# Altium schematic sheet EIT_CURRENTSTAGE.SchDoc
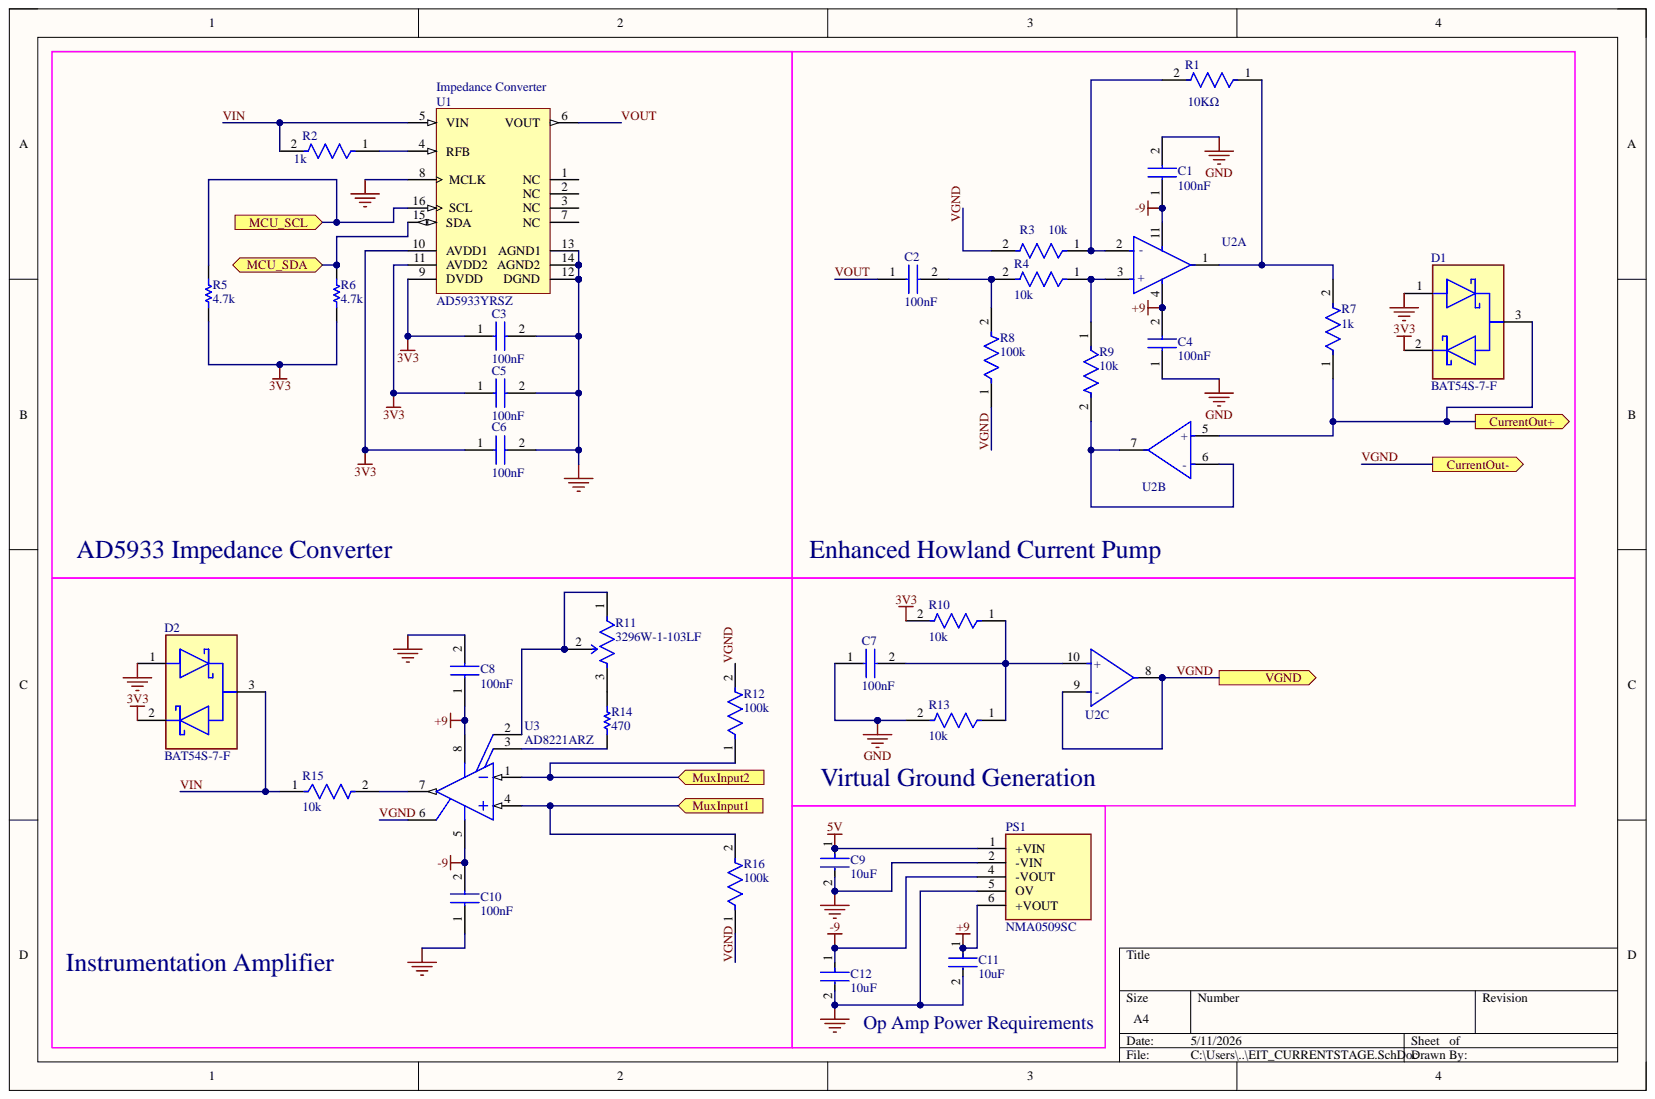
[diagram p0: EIT_CURRENTSTAGE.SchDoc]

## Parts
C1  100nF  [CAPC1608X90N]
C2  100nF  [CAPC1608X90N]
C3  100nF  [CAPC1608X90N]
C4  100nF  [CAPC1608X90N]
C5  100nF  [CAPC1608X90N]
C6  100nF  [CAPC1608X90N]
C7  100nF  [CAPC1608X90N]
C8  100nF  [CAPC1608X90N]
C9  10uF  [FP-CL21-IPC_B]
C10  100nF  [CAPC1608X90N]
C11  10uF  [FP-CL21-IPC_B]
C12  10uF  [FP-CL21-IPC_B]
D1  BAT54S-7-F  [FP-SOT23-MFG]
D2  BAT54S-7-F  [FP-SOT23-MFG]
PS1  NMA0509SC  [NMA0512SC]
R1  value 10KΩ  Resistance: 10KΩ  [FP-RT0603-MFG]  (hidden comment: RT0603BRD0710KL)
R2  1k  [FP-RT0603-MFG]
R3  10k  [FP-RT0603-MFG]
R4  10k  [FP-RT0603-MFG]
R5  4.7k  [RESC1608X55X25LL10T15]
R6  4.7k  [RESC1608X55X25LL10T15]
R7  1k  [FP-RT0603-MFG]
R8  100k  [FP-RQ731J-IPC_C]
R9  10k  [FP-RT0603-MFG]
R10  10k  [FP-RT0603-MFG]
R11  3296W-1-103LF  [FP-3296W-MFG]
R12  100k  [FP-RQ731J-IPC_C]
R13  10k  [FP-RT0603-MFG]
R14  470  [RESC1608X55X25LL10T15]
R15  10k  [FP-RT0603-MFG]
R16  100k  [FP-RQ731J-IPC_C]
U1  AD5933YRSZ  [ADI-RS-16_L]
U2  OPA4192IPW  [FP-PW0014A-IPC_C]  (value not drawn)  (parts A,B,C)
U3  AD8221ARZ  [ADI-R-8_M]

## Ports
CurrentOut+, CurrentOut-, MCU_SCL, MCU_SDA, MuxInput1, MuxInput2, VGND

## Nets
3V3: C3.1 C5.1 C6.1 D1.2 D2.2 R5.1 R6.1 R10.2 U1.9(DVDD) U1.10(AVDD1) U1.11(AVDD2)
5V: C9.1 PS1.1(+VIN)
+9: C4.2 C8.1 C11.1 PS1.6(+VOUT) U2.4 U3.8
-9: C1.1 C10.2 C12.1 PS1.4(-VOUT) U2.11 U3.5
GND: C1.2 C3.2 C4.1 C5.2 C6.2 C7.1 C8.2 C9.2 C10.1 C11.2 C12.2 D1.1 D2.1 PS1.2(-VIN) PS1.5(OV) R13.2 U1.8(MCLK) U1.12(DGND) U1.13(AGND1) U1.14(AGND2)
MCU_SCL: R5.2 U1.16(SCL) ; port MCU_SCL
MCU_SDA: R6.2 U1.15(SDA) ; port MCU_SDA
MuxInput1: R16.2 U3.4 ; port MuxInput1
MuxInput2: R12.1 U3.1 ; port MuxInput2
VGND: R3.2 R8.1 R12.2 R16.1 U2.8 U2.9(-) U3.6 ; port VGND
VIN: D2.3 R2.2 R15.1 U1.5(VIN)
VOUT: C2.1 U1.6(VOUT)
NetC2_2: C2.2 R4.2 R8.2
NetC7_2: C7.2 R10.1 R13.1 U2.10(+)
NetD1_3: D1.3 R7.1 U2.5(+)
NetR1_1: R1.1 R7.2 U2.1
NetR1_2: R1.2 R3.1 U2.2(-)
NetR2_1: R2.1 U1.4(RFB)
NetR4_1: R4.1 R9.1 U2.3(+)
NetR9_2: R9.2 U2.6(-) U2.7
NetR11_1: R11.1 R11.2 U3.2
NetR11_3: R11.3 R14.2
NetR14_1: R14.1 U3.3
NetR15_2: R15.2 U3.7

Not connected: U1 1(NC) 2(NC) 3(NC) 7(NC)

## Library data of the parts
C1-C8 C10 = CL10B104KB8NNNC: Capacitor; Manufacturer_Name: SAMSUNG; Arrow Part Number: CL10B104KB8NNNC; Arrow Price/Stock: https://www.arrow.com/en/products/cl10b104kb8nnnc/samsung-electro-mechanics?utm_currency=USD&region=nac; Component Kind: Standard; Datasheet Link: https://datasheet.datasheetarchive.com/originals/distributors/Datasheets-DGA10/2408969.pdf; Height: 0.9mm; Manufacturer_Part_Number: CL10B104KB8NNNC; Mouser Part Number: 187-CL10B104KB8NNNC; Mouser Price/Stock: https://www.mouser.co.uk/ProductDetail/Samsung-Electro-Mechanics/CL10B104KB8NNNC?qs=349EhDEZ59rvGc2rLwVOdA%3D%3D; Simulation: C_Capacitor_Default
C9 C11 C12 = CMP-2000-06102-2: CL21 Series 0805 10uF 16V ±10% Tolerance X5R Multilayer Ceramic Chip Capacitor; Dielectric: X7R; Capacitance: 10uF; Case Code (Imperial): 0805; Case Code (Metric): 2012; Case/Package: 0805; Component Kind: Standard; Depth: 1.25mm; Height: 1.25mm; Lead Free: Lead Free; Length: 2mm; Library Name: Altium Content Vault; Max Operating Temperature: 85°C; Min Operating Temperature: -55°C; Mount: Surface Mount; Package Quantity: 1; Packaging: Tape and Reel; Radiation Hardening: No; Thickness: 0.055inch; Tolerance: 10%; Voltage: 16V; Voltage Rating: 16V; Voltage Rating (DC): 16V; Width: 0.049inch
D1 D2 = CMP-1667-00006-2: DIODE ARRAY SCHOTTKY 30V SOT23-3; Average Rectified Current: 200mA; Capacitance: 10pF; Forward Voltage: 800mV; Max Forward Surge Current (Ifsm): 600mA; Max Power Dissipation: 200mW; Peak Reverse Current: 2uA; Reverse Recovery Time: 5ns; Case/Package: SOT-23; Component Kind: Standard; Contact Plating: Tin; Current Rating: 200mA; Forward Current: 200mA; Height: 1mm; Lead Free: Lead Free; Length: 2.9mm; Library Name: Altium Content Vault; Max Operating Temperature: 150°C; Max Repetitive Reverse Voltage (Vrrm): 30V; Max Reverse Voltage (DC): 30V; Min Operating Temperature: -65°C; Mount: Surface Mount; Number of Pins: 3; Package Quantity: 3000; Packaging: Tape and Reel; Peak Non-Repetitive Surge Current: 600mA; Power Dissipation: 200mW; Power Rating: 200mW; Radiation Hardening: No; REACH SVHC: No SVHC; Termination: SMD/SMT; Voltage Rating (DC): 30V; Weight: 0.000282oz; Width: 1.3mm
PS1 = NMA0509SC: Power Supply; Manufacturer_Name: Murata Electronics; Arrow Part Number: NMA0509SC; Arrow Price/Stock: https://www.arrow.com/en/products/nma0509sc/murata-power-solutions; Component Kind: Standard; Datasheet Link: https://power.murata.com/data/power/ncl/kdc_nma.pdf; Height: 10mm; Manufacturer_Part_Number: NMA0509SC; Mouser Part Number: 580-NMA0509SC; Mouser Price/Stock: https://www.mouser.co.uk/ProductDetail/Murata-Power-Solutions/NMA0509SC?qs=ddnKDgknAWSNA7R0noUR5A%3D%3D
R1 = CMP-03412-000619-1: RES 10.0K OHM 1/10W .1% SMD 0603; Manufacturer: Yageo; Power Rating: 0.1W; Temperature Coefficient: 25 ppm/°C; Tolerance: 0.001; Voltage Rating: 75V; Automotive: No; Category: Res; Component Kind: Standard; ComponentLink2Description: Datasheet; ComponentLink2URL: http://www.yageo.com/documents/recent/PYu-RT_1-to-0.01_RoHS_L_9.pdf; Composition: Thin Film; Device Class L1: Passive Components; Device Class L2: Resistors; Device Class L3: Chip SMD Resistors; Digikey Description: RES 10.0K OHM 1/10W .1% SMD 0603; Footprint Url: http://www.yageo.com/exep/pages/download/literatures/PYu-R_Mount_10.pdf; Height: 0.55mm; Ipc Land Pattern Name: RESC16080X45; Lead Free: Yes; Library Name: Altium Content Vault; Manufacturer Part Number: RT0603BRD0710KL; Mouser Description: Thin Film Resistors - SMD 10K ohm 0.1% 1/10W; Octopart: https://octopart.com/rt0603brd0710kl-yageo-1399221; Package: RT0603; RoHS: TRUE; Series: RT; Simulation: R_Resistor_Default; Temperature: -55°C to +155°C; Temperature Range High: +155°C; Temperature Range Low: -55°C
R2 R7 = CMP-03412-013082-1: RES SMD 1K OHM 0.1% 1/10W 0603; Manufacturer: Yageo; Manufacturer Part Number: RT0603BRD071KL; Power Rating: 0.1W; Resistance: 1kΩ; Temperature Coefficient: 25ppm/°C; Voltage Rating: 75V; Automotive: No; Category: Res; Component Kind: Standard; ComponentLink2Description: Datasheet; ComponentLink2URL: https://www.yageo.com/upload/media/product/productsearch/datasheet/rchip/PYu-RT_1-to-0.01_RoHS_L_11.pdf; Composition: Thin Film; Device Class L1: Passive Components; Device Class L2: Resistors; Device Class L3: Chip SMD Resistors; Digikey Description: RES SMD 1K OHM 0.1% 1/10W 0603; Footprint Url: https://www.yageo.com/upload/website/yageo_PYu-R_Mount_10_19050818_343.pdf; Height: 0.55mm; Lead Free: Yes; Library Name: Altium Content Vault; Octopart: https://octopart.com/rt0603brd071kl-yageo-1433776; Package: RT0603; RoHS: TRUE; Series: RT; Simulation: R_Resistor_Default; Temperature: -55°C to +155°C; Temperature Range High: +155°C; Temperature Range Low: -55°C; Tolerance: 0.1%
R3 R4 R9 R10 R13 R15 = CMP-03412-000619-1: RES 10.0K OHM 1/10W .1% SMD 0603; Manufacturer: Yageo; Manufacturer Part Number: RT0603BRD0710KL; Power Rating: 0.1W; Resistance: 10KΩ; Temperature Coefficient: 25 ppm/°C; Tolerance: 0.001; Voltage Rating: 75V; Automotive: No; Category: Res; Component Kind: Standard; ComponentLink2Description: Datasheet; ComponentLink2URL: http://www.yageo.com/documents/recent/PYu-RT_1-to-0.01_RoHS_L_9.pdf; Composition: Thin Film; Device Class L1: Passive Components; Device Class L2: Resistors; Device Class L3: Chip SMD Resistors; Digikey Description: RES 10.0K OHM 1/10W .1% SMD 0603; Footprint Url: http://www.yageo.com/exep/pages/download/literatures/PYu-R_Mount_10.pdf; Height: 0.55mm; Ipc Land Pattern Name: RESC16080X45; Lead Free: Yes; Library Name: Altium Content Vault; Mouser Description: Thin Film Resistors - SMD 10K ohm 0.1% 1/10W; Octopart: https://octopart.com/rt0603brd0710kl-yageo-1399221; Package: RT0603; RoHS: TRUE; Series: RT; Simulation: R_Resistor_Default; Temperature: -55°C to +155°C; Temperature Range High: +155°C; Temperature Range Low: -55°C
R5 R6 = CMP-2000-06942-1: Chip Resistor, 4.7 KOhm, +/- 1%, 0.1 W, -55 to 155 degC, 0603 (1608 Metric), RoHS, Tape and Reel; Manufacturer: Yageo; Power Rating: 100mW; Resistance: 4.7kOhms; Temperature Coefficient: 100PPM/C; Voltage Rating: 50V; Case Code - in: 0603; Case Code - mm: 1608; Component Kind: Standard; Datasheet URL: http://www.yageo.com/documents/recent/PYu-RC_Group_51_RoHS_L_7.pdf; Datasheet Version: Rev. 7, 05/2017; Library Name: Altium Content Vault; Manufacturer URL: http://www.yageo.com/; Mounting Technology: SurfaceMount; Operating Temperature Range: -55Cto+155C; Package Description: 2-Pin Surface Mount Device, Body 1.6 x 0.8 mm; Package Reference: 0603; Packaging: Reel; Product: ThickFilmResistorsGeneralPurpose; Series: RC; Termination Style: SMD/SMT; Tolerance: 1%
R8 R12 R16 = CMP-03211-001316-1: RQ73 0603 100KΩ  0.15W 10ppm/°C 0.1% 75V; Manufacturer: TE; Manufacturer Part Number: RQ73C1J100KBTD; Automotive Grade: Grade 0; Automotive: Yes; Category: Res; Component Kind: Standard; ComponentLink2Description: Datasheet; ComponentLink2URL: https://www.te.com/commerce/DocumentDelivery/DDEController?Action=showdoc&DocId=Data+Sheet%7F1773270-2%7FA%7Fpdf%7FEnglish%7FENG_DS_1773270-2_A.pdf%7F4-2176362-2; Composition: Thin Film; Device Class L1: Passive Components; Device Class L2: Resistors; Device Class L3: Chip SMD Resistors; Height: 0.6mm; Ipc Land Pattern Name: RESC15580X45; Lead Free: Yes; Library Name: Altium Content Vault; Octopart: https://octopart.com/rq73c1j100kbtd-te+connectivity-93908182; Package: RQ731J; Power Rating: 0.15W; Resistance: 100KΩ; RoHS: TRUE; Series: RQ73; Simulation: R_Resistor_Default; Temperature: -55°C to +155°C; Temperature Coefficient: 10ppm/°C; Temperature Range High: +155°C; Temperature Range Low: -55°C; Tolerance: 0.1%; Value: 100KΩ; Voltage Rating: 75V
R11 = CMP-1747-00001-8: TRIMMER 10K OHM 0.5W PC PIN TOP; Insulation Resistance: 1GR; Max Power Dissipation: 500mW; Number of Turns: 25; Resistance: 10kR; Resistance Tolerance: 10%; Temperature Coefficient: 100ppm/°C; Value: 10kR; Color: Blue; Component Kind: Standard; Contact Plating: Tin; Depth: 4.83mm; Height: 11.55mm; Lead Free: Lead Free; Length: 9.53mm; Library Name: Altium Content Vault; Max Operating Temperature: 125°C; Military Standard: Not; Min Operating Temperature: -55°C; Mount: Through Hole; Package Quantity: 50; Packaging: Rail/Tube; Power Rating: 500mW; Radiation Hardening: No; Reach SVHC Compliant: Yes; Resistor Type: Cermet; RoHS Compliant: Yes; Series: 3296; Simulation: R_Resistor_Default; Taper: Linear; Technology: CERMET; Termination: Pin; Tolerance: 10%; Weight: 0.03oz; Width: 9.53mm
R14 = CMP-1659-00035-2: General Purpose Chip Resistor, 470 Ohm, +/- 1%, -55 to 155 degC, 0603 (1608 Metric), RoHS, Tape and Reel; Resistance: 470 Ohm; Component Kind: Standard; ComponentLink1Description: Manufacturer URL; ComponentLink1URL: http://www.yageo.com/; ComponentLink2Description: Datasheet; ComponentLink2URL: https://datasheet.ciiva.com/11808/0900766b80f96399-11808177.pdf; Contact Material: Brass; Contact Plating: Gold; DatasheetVersion: Rev. 4, 04/2009; Fastening Type: Snap; Height: 1.25 mm; Length: 3 mm; Library Name: Altium Content Vault; Max Operating Temperature: 90 degC; Min Operating Temperature: -40 degC; Mount: Surface Mount; Package Quantity: 2500; PackageDescription: 2-Pin Surface Mount Device, Body 1.6 x 0.8 mm; PackageReference: 0603; Packaging: Tape and Reel; Radiation Hardening: No; RoHSCompliant: true; Width: 3.1 mm
U1 = CMP-0293-00046-1: 1 MSPS, 12-Bit Impedance Converter, Network Analyzer, Serial, RS-16, 77 Tube, Pb-Free; I Supply total (max): 15mA; Master fclk: 16.776MHz; Resolution (Bits): 12bit; Tuning Word Width (bits): 27bit; Code_JEDEC: MO-150-AC; Component Kind: Standard; ComponentLink1Description: Manufacturer URL; ComponentLink1URL: www.analog.com; ComponentLink2Description: Datasheet; ComponentLink2URL: http://www.analog.com/static/imported-files/data_sheets/AD5933.pdf; ComponentLink3Description: Package Specification; ComponentLink3URL: http://www.analog.com/static/imported-files/packages/31572257652246RS_16.pdf; DatasheetVersion: Rev. D; I/O Interface: Serial; Library Name: Altium Content Vault; PackageDescription: 16-Lead Shrink Small Outline Package [SSOP]; PackageReference: RS-16; PackageVersion: 3/17/2005
U2 = CMP-04895-000260-1: IC OPAMP GP 4 CIRCUIT 14TSSOP; Bandwidth: 10MHz; Input Bias Current: 5pA; Input Offset Voltage (Vos): 5uV; Number of Amplifiers: 4; Number of Circuits: 1; Operating Supply Current: 1mA; Output Current per Channel: 65mA; Slew Rate: 20V/µs; Case/Package: TSSOP; Component Kind: Standard; Gain Bandwidth Product: 10MHz; Lead Free: Lead Free; Library Name: Altium Content Vault; Max Operating Temperature: 125°C; Min Operating Temperature: -40°C; Mount: Surface Mount; Number of Channels: 4; Number of Pins: 14; Packaging: Rail/Tube; REACH SVHC: No SVHC; RoHS Compliant: Yes
U3 = CMP-0934-00045-1: Precision Instrumentation Amplifier, 2 V/us, -40 to 125 degC, 8-Pin SOIC (R-8), RoHS, Tube; Manufacturer: Analog Devices; -3dB Bandwidth@G: 1=825 kHz; Quiescent Current: 0.9 mA Typ.; Slew Rate@G: 1=2 V/us Typ.; VIO: 60 uV Max.; Component Kind: Standard; ComponentLink1Description: Manufacturer URL; ComponentLink1URL: http://www.analog.com/en/index.html; ComponentLink2Description: Datasheet; ComponentLink2URL: http://www.analog.com/static/imported-files/data_sheets/AD8221.pdf; ComponentLink3Description: Package Specification; ComponentLink3URL: http://www.analog.com/static/imported-files/packages/PKG_PDF/SOIC_Narrow%20(R)/R_8.pdf; DatasheetVersion: Rev. C; Library Name: Altium Content Vault; Mounting Technology: Surface Mount; PackageDescription: 8-Pin Standard Small Outline Package, Body 4.9 x 3.9 mm, Pitch 1.27 mm; PackageVersion: Jun-06; Packing: Tube; PartNumber: AD8221ARZ; RoHS: TRUE; Simulation: AD8221; Temperature Range: -40 to 125 degC
